# Altium schematic sheet EndStops.SchDoc(EndStops)
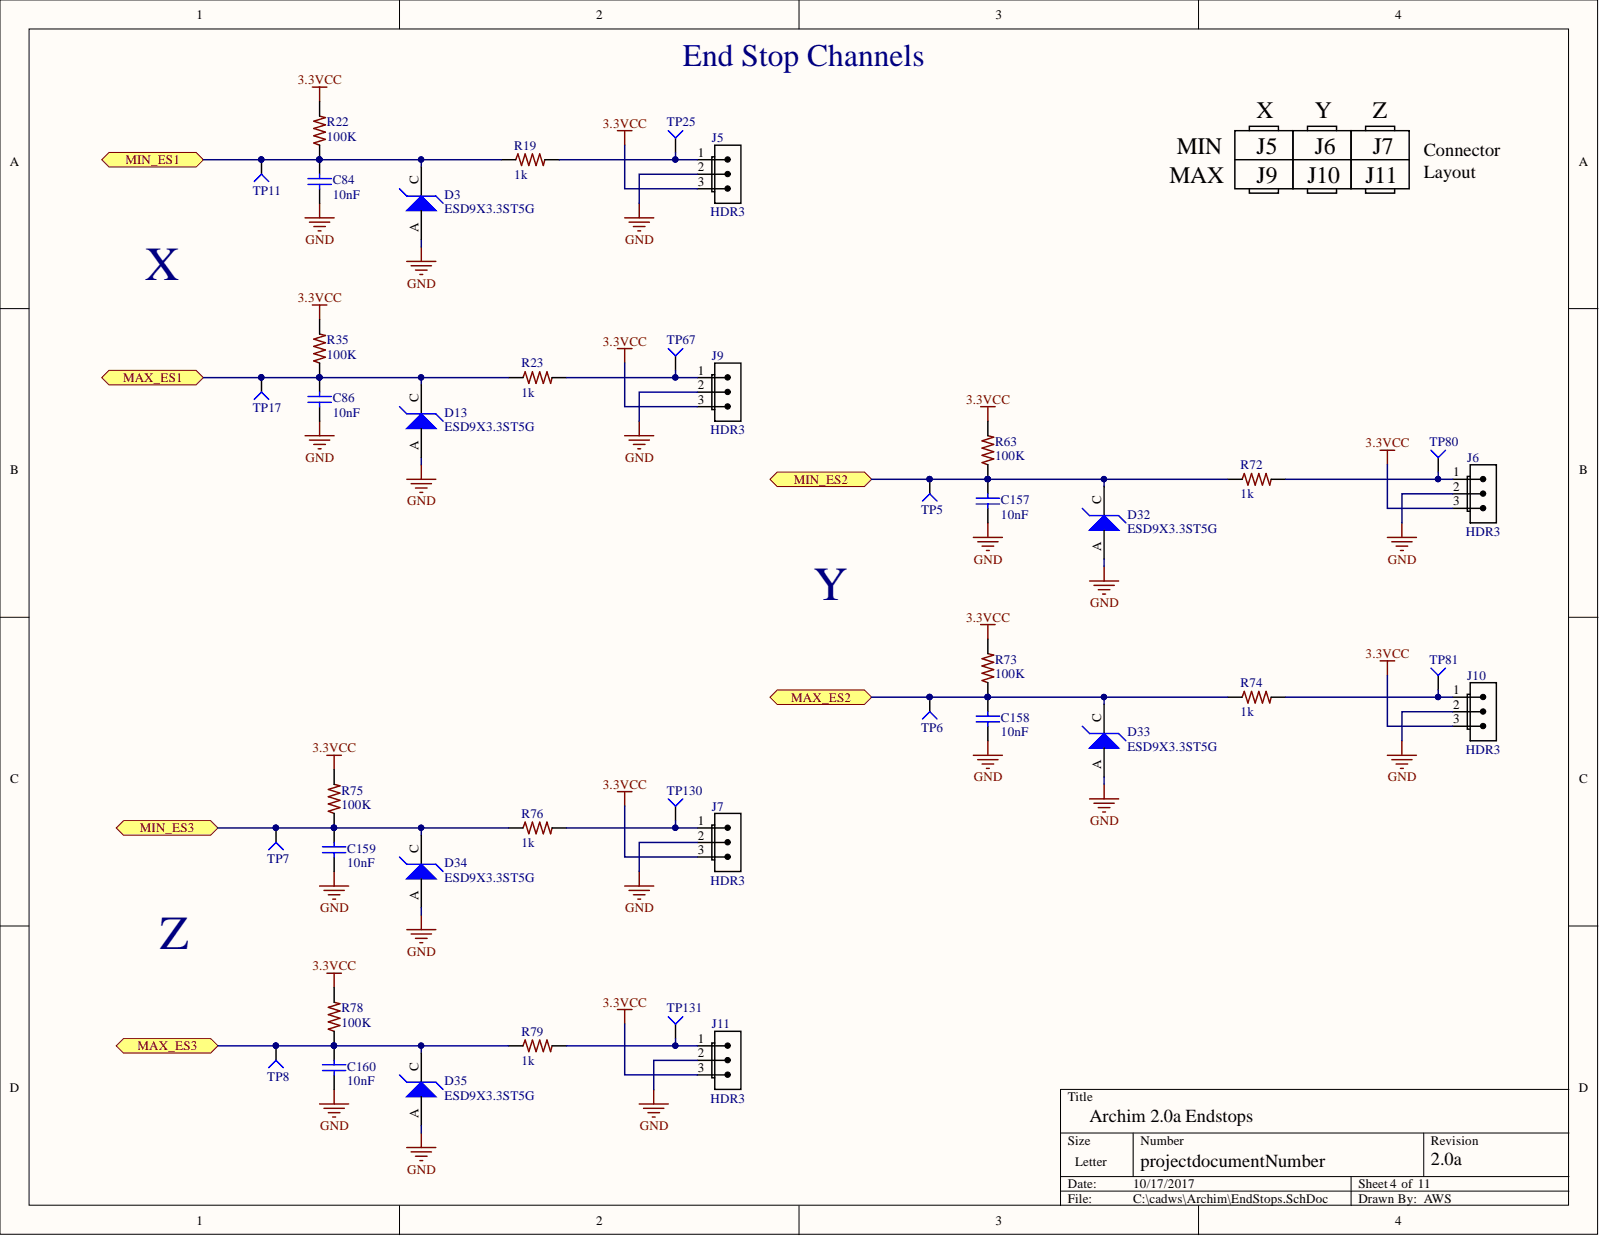
[diagram p0: EndStops.SchDoc]

## Parts
C84  10nF  [C0402]
C86  10nF  [C0402]
C157  10nF  [C0402]
C158  10nF  [C0402]
C159  10nF  [C0402]
C160  10nF  [C0402]
D3  ESD9X3.3ST5G  [SOD923-100X40-2N]
D13  ESD9X3.3ST5G  [SOD923-100X40-2N]
D32  ESD9X3.3ST5G  [SOD923-100X40-2N]
D33  ESD9X3.3ST5G  [SOD923-100X40-2N]
D34  ESD9X3.3ST5G  [SOD923-100X40-2N]
D35  ESD9X3.3ST5G  [SOD923-100X40-2N]
J5  value HDR3  [70543-03]  (hidden comment: Molex 70543-03)
J6  value HDR3  [70543-03]  (hidden comment: Molex 70543-03)
J7  value HDR3  [70543-03]  (hidden comment: Molex 70543-03)
J9  value HDR3  [70543-03]  (hidden comment: Molex 70543-03)
J10  value HDR3  [70543-03]  (hidden comment: Molex 70543-03)
J11  value HDR3  [70543-03]  (hidden comment: Molex 70543-03)
R19  1k  [R0402]
R22  100K  [R0402]
R23  1k  [R0402]
R35  100K  [R0402]
R63  100K  [R0402]
R72  1k  [R0402]
R73  100K  [R0402]
R74  1k  [R0402]
R75  100K  [R0402]
R76  1k  [R0402]
R78  100K  [R0402]
R79  1k  [R0402]
TP5  TP4  [TP-2]  (value not drawn)  (no BOM)
TP6  TP4  [TP-2]  (value not drawn)  (no BOM)
TP7  TP4  [TP-2]  (value not drawn)  (no BOM)
TP8  TP4  [TP-2]  (value not drawn)  (no BOM)
TP11  TP4  [TP-2]  (value not drawn)  (no BOM)
TP17  TP4  [TP-2]  (value not drawn)  (no BOM)
TP25  TP4  [TP-2]  (value not drawn)  (no BOM)
TP67  TP4  [TP-2]  (value not drawn)  (no BOM)
TP80  TP4  [TP-2]  (value not drawn)  (no BOM)
TP81  TP4  [TP-2]  (value not drawn)  (no BOM)
TP130  TP4  [TP-2]  (value not drawn)  (no BOM)
TP131  TP4  [TP-2]  (value not drawn)  (no BOM)

## Ports
MAX_ES1, MAX_ES2, MAX_ES3, MIN_ES1, MIN_ES2, MIN_ES3

## Nets
3.3VCC: J5.3 J6.3 J7.3 J9.3 J10.3 J11.3 R22.2 R35.2 R63.2 R73.2 R75.2 R78.2
GND: C84.2 C86.2 C157.2 C158.2 C159.2 C160.2 D3.A D13.A D32.A D33.A D34.A D35.A J5.2 J6.2 J7.2 J9.2 J10.2 J11.2
MAX_ES1: C86.1 D13.C R23.1 R35.1 TP17.1 ; port MAX_ES1
MAX_ES2: C158.1 D33.C R73.1 R74.1 TP6.1 ; port MAX_ES2
MAX_ES3: C160.1 D35.C R78.1 R79.1 TP8.1 ; port MAX_ES3
MIN_ES1: C84.1 D3.C R19.1 R22.1 TP11.1 ; port MIN_ES1
MIN_ES2: C157.1 D32.C R63.1 R72.1 TP5.1 ; port MIN_ES2
MIN_ES3: C159.1 D34.C R75.1 R76.1 TP7.1 ; port MIN_ES3
NetJ5_1: J5.1 R19.2 TP25.1
NetJ6_1: J6.1 R72.2 TP80.1
NetJ7_1: J7.1 R76.2 TP130.1
NetJ9_1: J9.1 R23.2 TP67.1
NetJ10_1: J10.1 R74.2 TP81.1
NetJ11_1: J11.1 R79.2 TP131.1

## Library data of the parts
C84 C86 C157-C160 = GRM155R71H103JA88D: CAP CER 10nF 50V X7R 0402; PART DESCRIPTION: 10000pF ±5% 50V Ceramic Capacitor X7R 0402 (1005 Metric); MANUFACTURER: Murata; TOLERANCE: 5%; VALUE: 10nF; Simulation: CAP; Signal Integrity: Cap Semi; PART: 10nF 50V X7R 0402; MANUFACTURER #: GRM155R71H103JA88D; Library Name: Tigershark3D.SchLib; EE_SPEC: 50V; DISTRIBUTOR #: 490-7763-1-ND; DISTRIBUTOR: Digi-Key Electronics; Component Kind: Standard
D3 D13 D32-D35 = ESD9X3.3ST5G: TVS DIODE 3.3VWM 10.4VC SOD923; PART DESCRIPTION: TVS DIODE 3.3VWM SOD923; MANUFACTURER: On Semi; VALUE: 3.3V; TOLERANCE: -NA-; EE_SPEC: 3.3Vso 15pF; PART: TVS DIODE 3.3VWM SOD923; MANUFACTURER #: ESD9X3.3ST5G; DISTRIBUTOR #: ESD9X3.3ST5GOSCT-ND; DISTRIBUTOR: Digi-Key Electronics; Component Kind: Standard; Library Name: Archim_0.4.SCHLIB
J5-J7 J9-J11 = CONN 3POS VERT 0.1" SHRD LOCKING: 3 Positions Header, Shrouded Connector 0.100" (2.54mm) Through Hole Gold; PART: 3 Pin Conn VERT; MANUFACTURER #: 0705430002; TOLERANCE: -NA-; PART DESCRIPTION: 3 Positions Header, Shrouded Connector 0.100" (2.54mm) Through Hole Gold; MANUFACTURER: Molex; EE_SPEC: -NA-; DISTRIBUTOR #: WM4801-ND; DISTRIBUTOR: Digi-Key Electronics; Component Kind: Standard; Library Name: Archim_0.4.SCHLIB
R19 R23 R72 R74 R76 R79 = RK73H1ETTP1001F: RES 0402 1k 63mW 1%; PART DESCRIPTION: 1k Ohm ±1% 0.063W, 1/16W Chip Resistor 0402 (1005 Metric) Moisture Resistant Thick Film; PART: 1k 1% 0402; MANUFACTURER #: RC0402FR-071KL; MANUFACTURER: Yageo; EE_SPEC: 1/16W; VALUE: 1k; TOLERANCE: 1%; Simulation: Model Name; Signal Integrity: Model Name; Library Name: 3Delight.SCHLIB; DISTRIBUTOR #: 311-1.00KLRTR-ND; DISTRIBUTOR: Digi-Key Electronics; Component Kind: Standard
R22 R35 R63 R73 R75 R78 = RC1005F104CS: RES SMD 100K OHM 1% 1/16W 0402; PART DESCRIPTION: 100k Ohm ±1% 0.063W, 1/16W Chip Resistor 0402 (1005 Metric) Moisture Resistant Thick Film; PART: 100k 1% 0402; MANUFACTURER: Samsung; VALUE: 100K; TOLERANCE: 1%; Simulation: Model Name; Signal Integrity: Model Name; MANUFACTURER #: RC1005F104CS; Library Name: Tigershark3D.SchLib; EE_SPEC: 1/16W; DISTRIBUTOR #: 1276-3432-1-ND; DISTRIBUTOR: Digi-Key Electronics; Component Kind: Standard
TP5-TP8 TP11 TP17 TP25 TP67 TP80 TP81 TP130 TP131 = Socket: MANUFACTURER #: NOTAPART-Testpoint; Signal Integrity: Connector; Description: Socket; Library Name: Archim.SCHLIB
